# Altium schematic sheet 02_Beam_Inputs.SchDoc(02_Beam_Inputs)
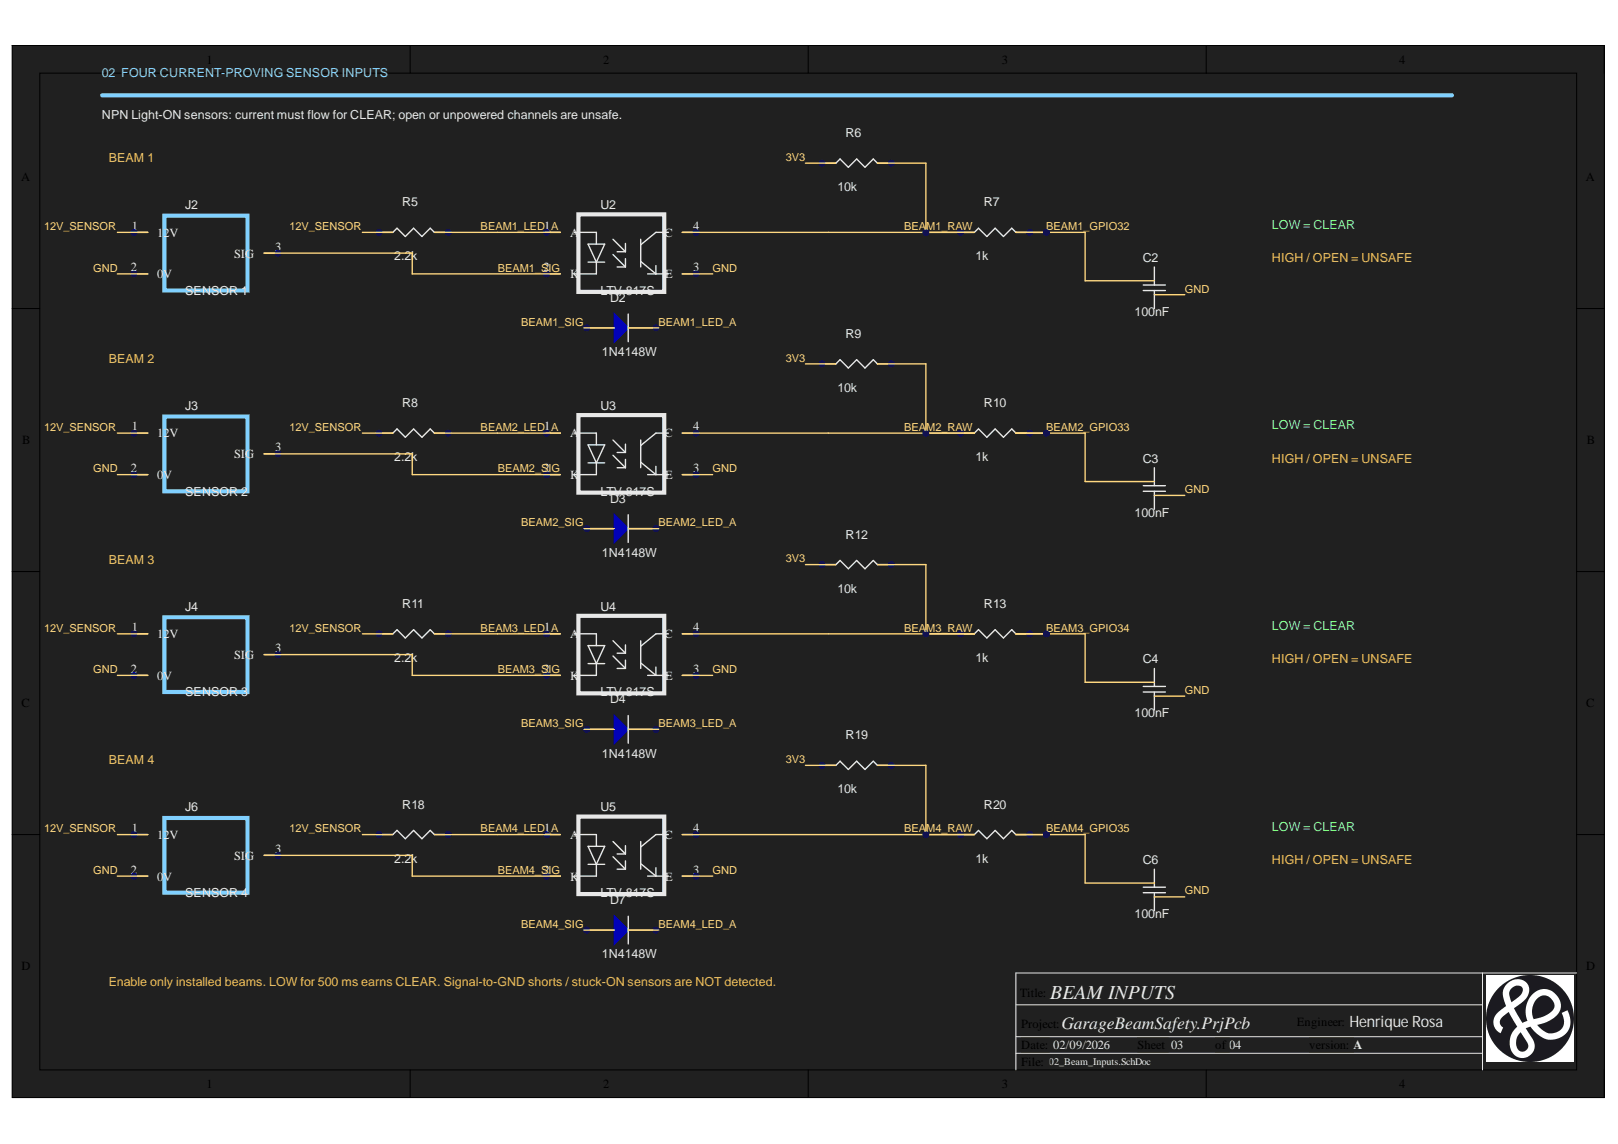
[diagram p0: 02_Beam_Inputs.SchDoc]

## Parts
C2  100nF
C3  100nF
C4  100nF
C6  100nF
D2  1N4148W
D3  1N4148W
D4  1N4148W
D7  1N4148W
J2  SENSOR 1
J3  SENSOR 2
J4  SENSOR 3
J6  SENSOR 4
R5  2.2k
R6  10k
R7  1k
R8  2.2k
R9  10k
R10  1k
R11  2.2k
R12  10k
R13  1k
R18  2.2k
R19  10k
R20  1k
U2  LTV-817S
U3  LTV-817S
U4  LTV-817S
U5  LTV-817S

## Nets
3V3: R6.1 R9.1 R12.1 R19.1
12V_SENSOR: J2.1 J3.1 J4.1 J6.1 R5.1 R8.1 R11.1 R18.1
BEAM1_GPIO32: R7.2
BEAM1_LED_A: D2.2 R5.2 U2.1(A)
BEAM1_RAW: R6.2 R7.1 U2.4(C)
BEAM1_SIG: D2.1 J2.3 U2.2(K)
BEAM2_GPIO33: R10.2
BEAM2_LED_A: D3.2 R8.2 U3.1(A)
BEAM2_RAW: R9.2 R10.1 U3.4(C)
BEAM2_SIG: D3.1 J3.3 U3.2(K)
BEAM3_GPIO34: R13.2
BEAM3_LED_A: D4.2 R11.2 U4.1(A)
BEAM3_RAW: R12.2 R13.1 U4.4(C)
BEAM3_SIG: D4.1 J4.3 U4.2(K)
BEAM4_GPIO35: R20.2
BEAM4_LED_A: D7.2 R18.2 U5.1(A)
BEAM4_RAW: R19.2 R20.1 U5.4(C)
BEAM4_SIG: D7.1 J6.3 U5.2(K)
GND: J2.2 J3.2 J4.2 J6.2 U2.3(E) U3.3(E) U4.3(E) U5.3(E)

Not connected: C2 1 2; C3 1 2; C4 1 2; C6 1 2

## Library data of the parts
C2-C4 C6 = Capacitor Cerâmico SMD: Input RC filter; Manufacturer: Murata; Manufacturer Part Number: GRM21BR71H104KA01L; CAD status: Symbol ready; footprint and STEP verification pending; cod.: 186030; Intended footprint: 0805; Symbol source: Conventional symbol reused from ENERGY.SchDoc; Component Kind: Standard; Library Name: Miscellaneous Devices.IntLib; Valor: 100nF
D2-D4 D7 = Diode: Reverse clamp: A to SIG; K to opto LED anode; Manufacturer: Nexperia; Part Number: FDLL4148; CAD status: Symbol ready; footprint and STEP verification pending; Intended footprint: SOD123; Symbol source: Conventional symbol reused from ENERGY.SchDoc; Code_JEDEC: DO-214-AB; Component Kind: Standard; LatestRevisionDate: 17-Jul-2002; LatestRevisionNote: Re-released for DXP Platform.; Library Name: Miscellaneous Devices.IntLib; Manufacturer Part Number: 1N4148W; PackageDocument: Sep-1996; PackageReference: SMC; Value: value
J2-J4 J6 = (no symbol name): 12V / GND / NPN signal terminal; Manufacturer: Phoenix Contact; Manufacturer Part Number: 1729131; CAD status: Symbol ready; footprint and STEP verification pending; Intended footprint: TERM_3_508; Populate: Yes; Component Kind: Standard; Package: TERM_3_508
R5 R8 R11 R18 = Res3: Optocoupler LED current limit; Manufacturer: Yageo; Manufacturer Part Number: RC0805FR-072K2L; CAD status: Symbol ready; footprint and STEP verification pending; cod.: 185070; Grupo: 01; Intended footprint: 0805; Symbol source: Conventional symbol reused from ENERGY.SchDoc; Valor: 4k7; Component Kind: Standard; Library Name: Miscellaneous Devices.IntLib
R6 R9 R12 R19 = Res3: Pull-up earns unsafe if optocoupler is off; Manufacturer: Yageo; Manufacturer Part Number: RC0805FR-0710KL; CAD status: Symbol ready; footprint and STEP verification pending; cod.: 185070; Grupo: 01; Intended footprint: 0805; Symbol source: Conventional symbol reused from ENERGY.SchDoc; Valor: 4k7; Component Kind: Standard; Library Name: Miscellaneous Devices.IntLib
R7 R10 R13 R20 = Res3: Input current limit; Manufacturer: Yageo; Manufacturer Part Number: RC0805FR-071KL; CAD status: Symbol ready; footprint and STEP verification pending; cod.: 185070; Grupo: 01; Intended footprint: 0805; Symbol source: Conventional symbol reused from ENERGY.SchDoc; Valor: 4k7; Component Kind: Standard; Library Name: Miscellaneous Devices.IntLib
U2-U5 = (no symbol name): Phototransistor optocoupler; Manufacturer: Lite-On; Manufacturer Part Number: LTV-817S-TA1; CAD status: Symbol ready; footprint and STEP verification pending; Intended footprint: SMD4; Populate: Yes; Component Kind: Standard; Package: SMD4
